# Altium schematic sheet main.SchDoc
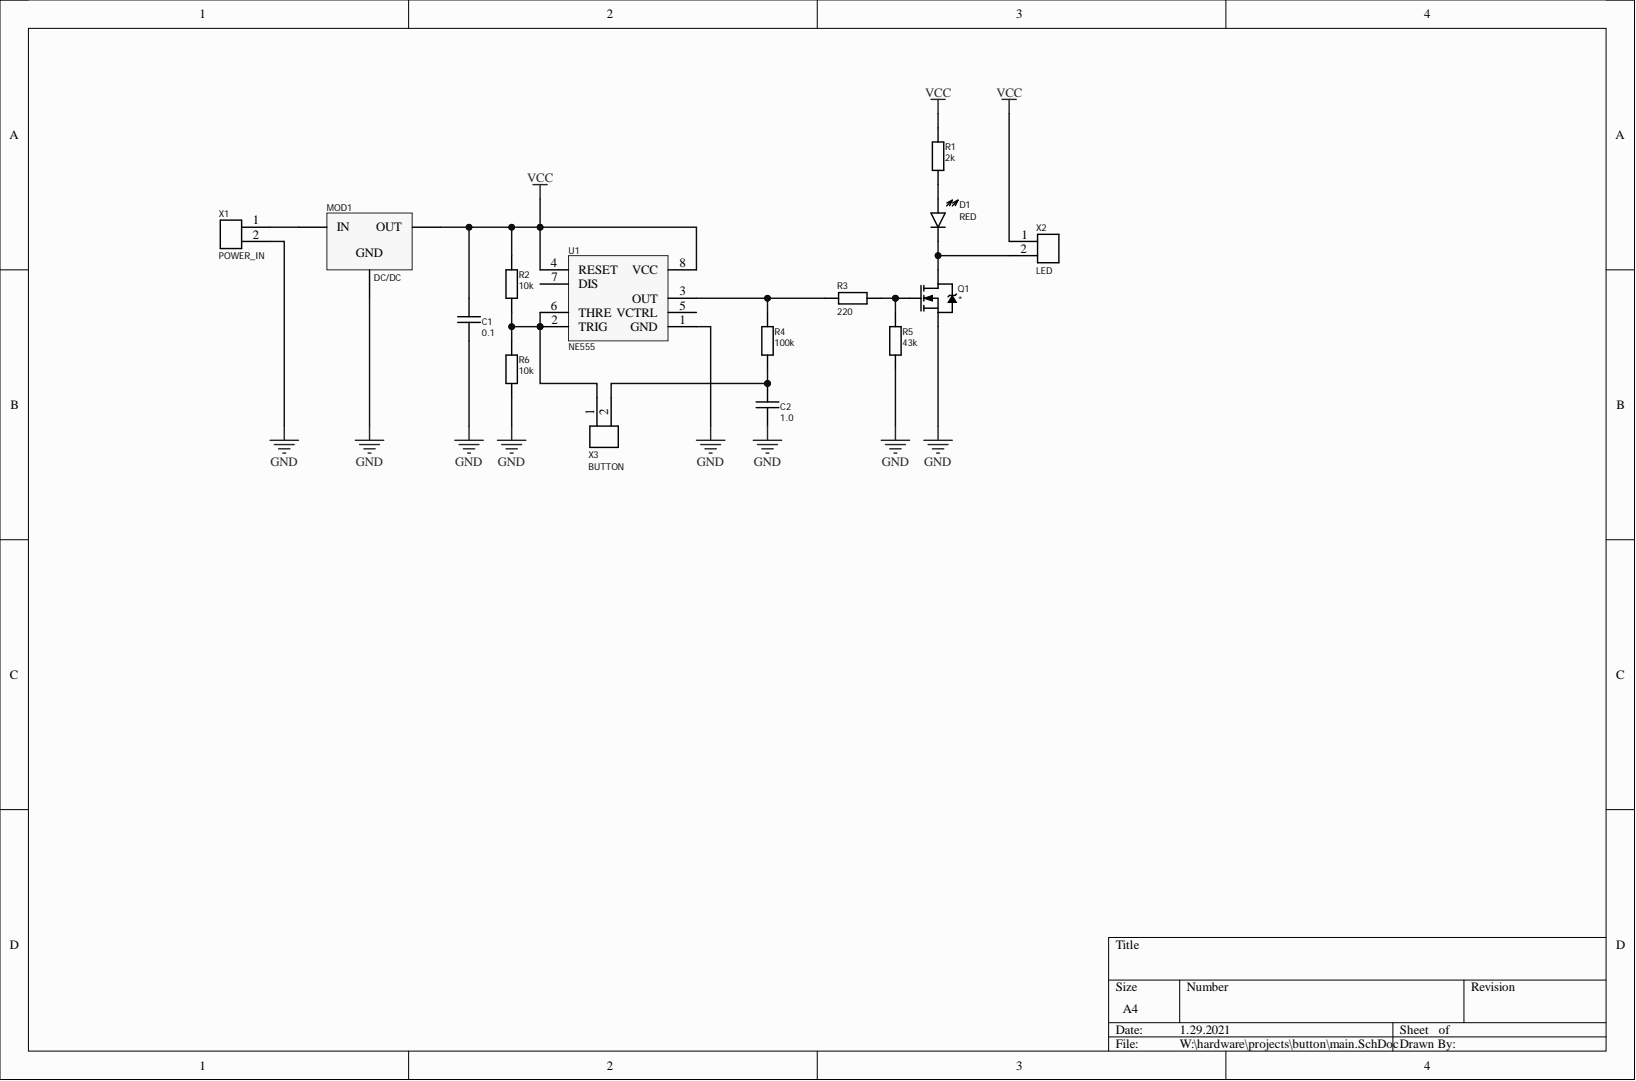
[diagram p0: main.SchDoc]

## Parts
C1  0.1  [C-0805]
C2  1.0  [C-0805]
D1  RED  [LED-0805]
MOD1  DC/DC  [PWR_BRD_MP1384EN]
Q1  (no value)  [SOT-23-GSD]
R1  2k  [R-0805]
R2  10k  [R-0805]
R3  220  [R-0805]
R4  100k  [R-0805]
R5  43k  [R-0805]
R6  10k  [R-0805]
U1  NE555  [SO-8]
X1  POWER_IN  [KF301-2P]
X2  LED  [KF301-2P]
X3  BUTTON  [KF301-2P]

## Nets
GND: C1.2 C2.2 MOD1.GND Q1.S R5.1 R6.1 U1.1(GND) X1.2
VCC: C1.1 MOD1.OUT R1.2 R2.2 U1.4(RESET) U1.8(VCC) X2.1
NetC2_1: C2.1 R4.1 X3.2
NetD1_A: D1.A R1.1
NetD1_C: D1.C Q1.D X2.2
NetMOD1_IN: MOD1.IN X1.1
NetQ1_G: Q1.G R3.2 R5.2
NetR2_1: R2.1 R6.2 U1.2(TRIG) U1.6(THRE) X3.1
NetR3_1: R3.1 R4.2 U1.3(OUT)

Not connected: U1 5(VCTRL) 7(DIS)

## Library data of the parts
C1 C2 = CAPACITOR: Component Kind: Standard; Library Name: all-in-one.IntLib
  C1: value: 0.1
  C2: value: 1.0
D1 = LED: Component Kind: Standard; Library Name: all-in-one.IntLib; value: RED
MOD1 = POWER_SUPPLY_ADJ: Component Kind: Standard; Library Name: all-in-one.IntLib
Q1 = MOSFET-N: Component Kind: Standard; Description: MOSFET-N; Library Name: all-in-one.IntLib
R1-R6 = RESISTOR: Component Kind: Standard; Library Name: all-in-one.IntLib
  R1: value: 2k
  R2 R6: value: 10k
  R3: value: 220
  R4: value: 100k
  R5: value: 43k
U1 = SE555: Component Kind: Standard; helpURL: w:/pdf/se555.pdf; Library Name: all-in-one.IntLib; value: NE555
X1-X3 = PINHEAD-2x1: Component Kind: Standard; Library Name: all-in-one.IntLib
